# Altium schematic sheet BLDC_Motor_Reverser.SchDoc(BLDC_Motor_Reverser)
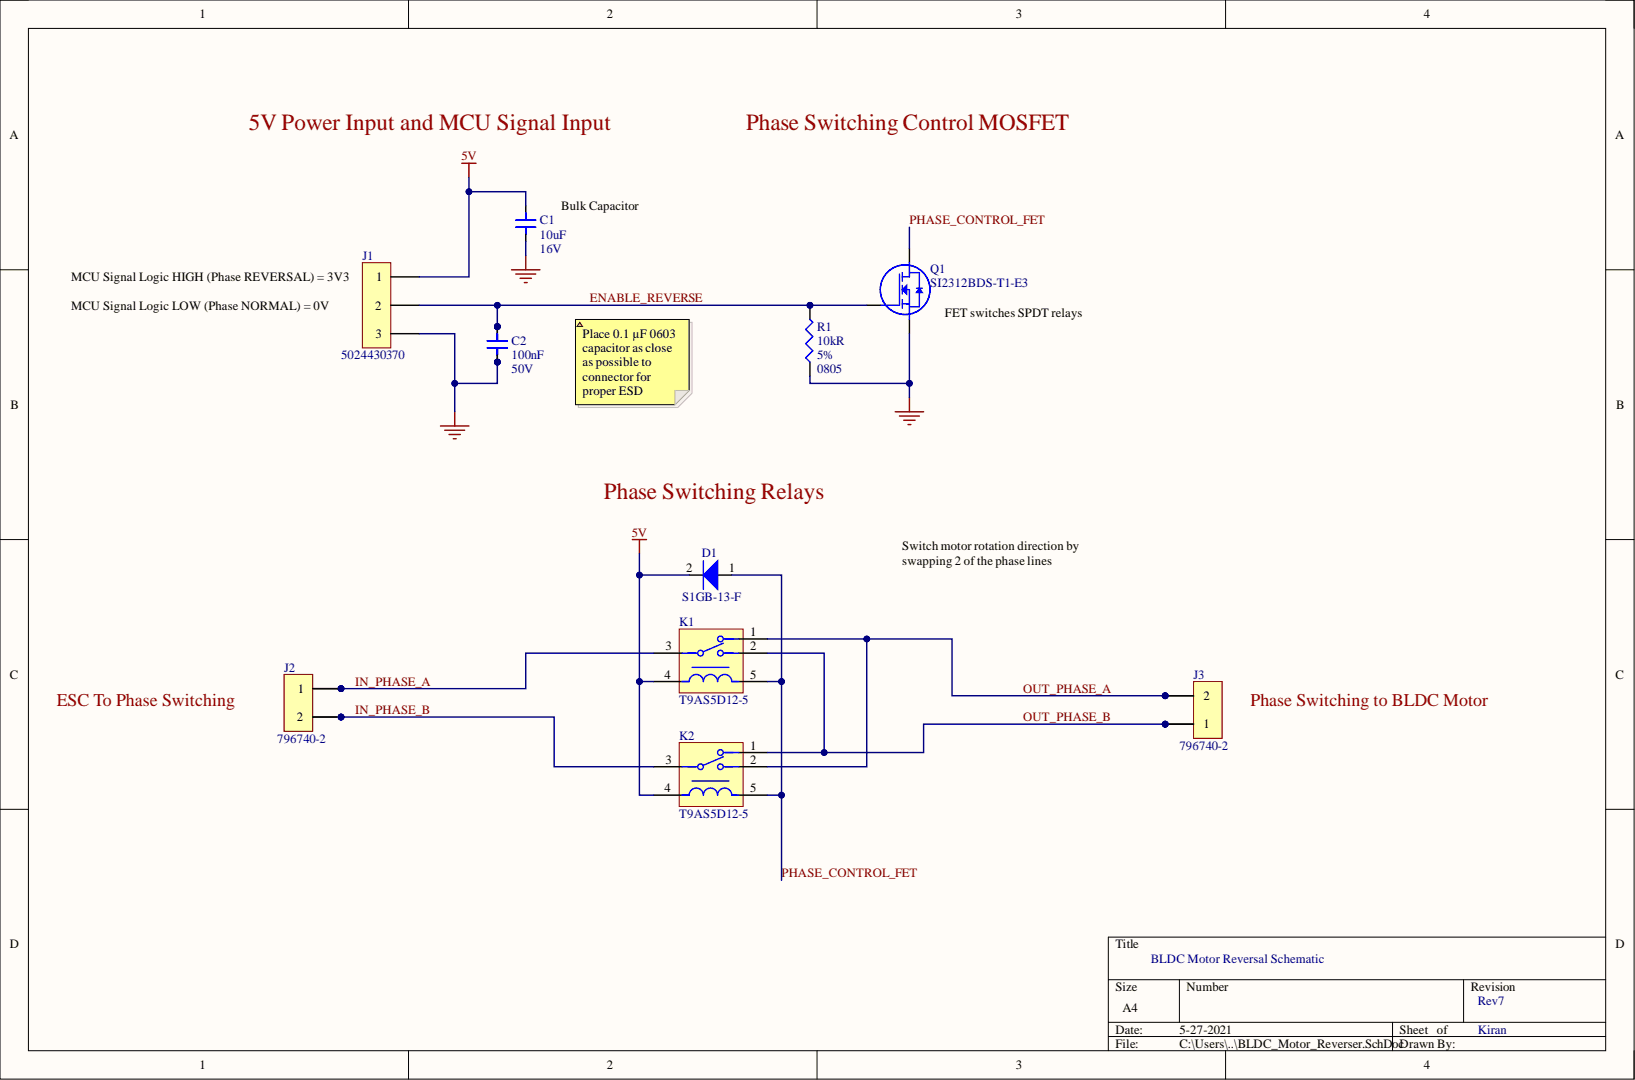
[diagram p0: BLDC_Motor_Reverser.SchDoc]

## Parts
C1  16V  Capacitance: 10uF  [CAP_0805_140mm]
C2  50V  Capacitance: 100nF  [CAP_0805_88mm]
D1  S1GB-13-F  [S1GB-13-F_DIODE]
J1  5024430370  [5024430370_MOLEX_CONN]
J2  796740-2  [CONN_796740-2]
J3  796740-2  [CONN_796740-2]
K1  T9AS5D12-5  [T9AS5D12-5_SPDT_RELAY]
K2  T9AS5D12-5  [T9AS5D12-5_SPDT_RELAY]
Q1  SI2312BDS-T1-E3  [SOT95P237X112-3N_NMOSFET]
R1  0805  Resistance: 10kR  Resistance Tolerance: 5%  [R0805_60mm]

## Nets
5V: C1.2 D1.2 J1.1 K1.4 K2.4
GND: C1.1 C2.1 J1.3 Q1.2 R1.1
ENABLE_REVERSE: C2.2 J1.2 Q1.1 R1.2
IN_PHASE_A: J2.1 K1.3
IN_PHASE_B: J2.2 K2.3
OUT_PHASE_A: J3.2 K1.1 K2.2
OUT_PHASE_B: J3.1 K1.2 K2.1
PHASE_CONTROL_FET: D1.1 K1.5 K2.5 Q1.3

## Library data of the parts
C1 = C0805C106K4PACTU: CERAMIC CAPACITOR, 10UF, 16V, X5R, 10%, 0805; Manufacturer: KEMET; Dissipation Factor: 5%; Case Code (Imperial): 0805; Case Code (Metric): 2012; Case/Package: 0805; Category: Ceramic Capacitors; Component Kind: Standard; ComponentLink1Description: https://datasheet.ciiva.com/7842/1732713-7842196.pdf?src-supplier=Element14; ComponentLink1URL: https://datasheet.ciiva.com/7842/1732713-7842196.pdf?src-supplier=Element14; ComponentLink2Description: https://datasheet.ciiva.com/11787/0900766b8116eb59-11787314.pdf?src-supplier=RSComponents; ComponentLink2URL: https://datasheet.ciiva.com/11787/0900766b8116eb59-11787314.pdf?src-supplier=RSComponents; ComponentLink3Description: https://datasheet.ciiva.com/28682/1831760-28682305.pdf?src-supplier=Element14; ComponentLink3URL: https://datasheet.ciiva.com/28682/1831760-28682305.pdf?src-supplier=Element14; ComponentLink4Description: https://datasheet.ciiva.com/26847/getdatasheetpartid-110990-26847815.pdf?src-supplier=Verical; ComponentLink4URL: https://datasheet.ciiva.com/26847/getdatasheetpartid-110990-26847815.pdf?src-supplier=Verical; ComponentLink5Description: https://datasheet.ciiva.com/7842/1732713-7842197.pdf?src-supplier=Element14; ComponentLink5URL: https://datasheet.ciiva.com/7842/1732713-7842197.pdf?src-supplier=Element14; Construction: Flat; Contact Plating: Tin,Matte; Depth: 1.25mm; Dielectric: X5R; Dielectric Material: Ceramic; Height: 1.25mm; Lead Free: Lead Free; Length: 2mm; Library Name: BLDC_Motor_Lib.SchLib; Manufacturer Part Number: C0805C106K4PACTU; Max Operating Temperature: 85°C; Min Operating Temperature: -55°C; Mount: Surface Mount; Number of Pins: 2; Package Quantity: 1; Packaging: Tape and Reel; Pricing 1: 1=0.21000, 10=0.14700, 50=0.08340, 100=0.06900, 500=0.04840, 1000=0.04007 (USD); Radiation Hardening: No; REACH SVHC: No SVHC; RoHS: Yes; Series: C; Stock 1: 386550; Supplier 1: Digi-Key; Supplier Part Number 1: 399-8012-1-ND; Termination: SMD/SMT; Thickness: 0.055inch; Tolerance: 10%; Voltage: 16V; Voltage Rating: 16V; Voltage Rating (DC): 16V; Width: 0.049inch
C2 = C0805C104K5RACTU: Cap Ceramic 0.1uF 50V X7R 10% SMD 2.0 x 1.2 mm 125 C Paper T/R; Manufacturer: KEMET; Voltage: 500V; Case Code (Imperial): 0805; Case Code (Metric): 2012; Case/Package: 0805; Category: Ceramic Capacitors; Component Kind: Standard; ComponentLink1Description: https://datasheet.ciiva.com/28682/1851736-28682232.pdf?src-supplier=Element14; ComponentLink1URL: https://datasheet.ciiva.com/28682/1851736-28682232.pdf?src-supplier=Element14; ComponentLink2Description: https://datasheet.ciiva.com/14589/70095383-14589048.pdf?src-supplier=Allied+Electronics; ComponentLink2URL: https://datasheet.ciiva.com/14589/70095383-14589048.pdf?src-supplier=Allied+Electronics; ComponentLink3Description: https://datasheet.ciiva.com/32431/slceramic-chip-condensers-e-32431755.pdf?src-supplier=Distrelec; ComponentLink3URL: https://datasheet.ciiva.com/32431/slceramic-chip-condensers-e-32431755.pdf?src-supplier=Distrelec; ComponentLink4Description: https://datasheet.ciiva.com/18410/70095383-18410815.pdf?src-supplier=Allied+Electronics; ComponentLink4URL: https://datasheet.ciiva.com/18410/70095383-18410815.pdf?src-supplier=Allied+Electronics; Construction: Flat; Contact Plating: Tin,Matte; Depth: 1.25mm; Dielectric: X7R; Dielectric Material: Ceramic; Height: 0.9mm; Lead Pitch: 0.75mm; Length: 2mm; Library Name: BLDC_Motor_Lib.SchLib; Manufacturer Part Number: C0805C104K5RACTU; Max Operating Temperature: 125°C; Min Operating Temperature: -55°C; Mount: Surface Mount,PCB; Number of Pins: 2; Package Quantity: 4000; Packaging: Tape and Reel; Pricing 1: 1=0.15000, 10=0.11000, 50=0.06220, 100=0.05150, 500=0.03612, 1000=0.02991 (USD); Radiation Hardening: No; REACH SVHC: No SVHC; RoHS: Yes; RoHS Compliant: Yes; Series: C0805C; Stock 1: 1084228; Supplier 1: Digi-Key; Supplier Part Number 1: 399-1170-1-ND; Termination: SMD/SMT; Thickness: 0.035inch; Tolerance: 10%; Voltage Rating: 50V; Voltage Rating (DC): 50V; Width: 1.2mm
D1 = S1GB-13-F: Flyback Diode for SPDT; IFSM: 8.0A; VRRM: 325V; Component Kind: Standard; Library Name: BLDC_Motor_Lib.SchLib
J1 = 5024430370: Molex Connectors for power and microcontroller signal; Component Kind: Standard; Library Name: BLDC_Motor_Lib.SchLib
J2 J3 = 796740-2: TERMINAL BLOCK, PLUG, 2POS, 24-10AWG; Connector Type:Eurostyle Terminal Block; Series:-; Connector Mounting:PCB; Pitch Spacing:6.35mm; No. of Contacts:2; Contact Plating:Tin; Contact Material:Phosphor Bronze; Manufacturer: TE Connectivity; Approvals: UL; Current: 30A; Interlock: Yes (With); Max Supply Voltage (AC): 300V; Number of Circuits: 2; Number of Levels: 1; Pitch: 6.35mm; Plating: Brass; Screw Flange: No (Without); Tail Length: 0.197inch; Thread Size: M3; Wire Gauge (Max): 24AWG; Category: Terminal Blocks; Color: Green; Component Kind: Standard; ComponentLink1Description: https://datasheet.ciiva.com/823/eng-cd-796740-c1-823477.pdf?src-supplier=Digi-Key; ComponentLink1URL: https://datasheet.ciiva.com/823/eng-cd-796740-c1-823477.pdf?src-supplier=Digi-Key; ComponentLink2Description: https://datasheet.ciiva.com/23766/eng-cd-796740-c1-23766211.pdf?src-supplier=Newark; ComponentLink2URL: https://datasheet.ciiva.com/23766/eng-cd-796740-c1-23766211.pdf?src-supplier=Newark; ComponentLink3Description: https://datasheet.ciiva.com/3141/eng-cs-1308389-eurostyle-terminal-blocks-0607-3141691.pdf?src-supplier=Avnet; ComponentLink3URL: https://datasheet.ciiva.com/3141/eng-cs-1308389-eurostyle-terminal-blocks-0607-3141691.pdf?src-supplier=Avnet; ComponentLink4Description: https://datasheet.ciiva.com/30130/eng-cs-1308389-eurostyle-terminal-blocks-0607-30130345.pdf?src-supplier=Onlinecomponents.com; ComponentLink4URL: https://datasheet.ciiva.com/30130/eng-cs-1308389-eurostyle-terminal-blocks-0607-30130345.pdf?src-supplier=Onlinecomponents.com; ComponentLink5Description: https://datasheet.ciiva.com/1416/1650926-1416332.pdf?src-supplier=Element14; ComponentLink5URL: https://datasheet.ciiva.com/1416/1650926-1416332.pdf?src-supplier=Element14; Connector Type: Plug; Contact Material: Brass; Contact Plating: Tin; Current Rating: 30A; Depth: 12.5mm; ELV: Compliant; Flammability Rating: UL94 V-0; Housing Color: Green; Housing Material: Polyamide; Lead Free: Lead Free; Length: 13.3mm; Library Name: BLDC_Motor_Lib.SchLib; Manufacturer Part Number: 796740-2; Max Current Rating: 30A; Max Voltage Rating (AC): 300V; Mount: Through Hole; Number of Contacts: 2; Number of Poles: 2; Number of Positions: 2; Number of Positions Per Level: 2; Number of Rows: 1; Orientation: Right Angle; Package Quantity: 200; Packaging: Carton; Pricing 1: 1=2.05000, 10=1.55100, 25=1.43760, 50=1.29260, 100=1.26100, 250=1.10336, 500=1.00878, 1000=0.91421, 5000=0.80388 (USD); Radiation Hardening: No; RoHS: Yes; RoHS Compliant: Yes; Screwless Terminal Block: No (Without); Series: Buchanan; Shrouded: No (Without); Side Stackable: No (Without); Stock 1: 2698; Supplier 1: Digi-Key; Supplier Part Number 1: A98275-ND; Termination: Screw; Voltage: 300V; Voltage Rating: 300V; Wire Gauge (Min): 24AWG
K1 K2 = T9AS5D12-5: RELAY GEN PURPOSE SPDT 20A 5V; Coil Current: 200mA; Coil Power: 1W; Coil Resistance: 25R; Coil Type: Non-Latching; Contact Voltage Rating (AC): 277V; Current Rating: 30A; Max Voltage Rating (DC): 28V; Operate Time: 15ms; Relay Type: General Purpose; Coil Voltage (DC): 5V; Component Kind: Standard; Contact Current Rating: 20A; Contact Material: Silver; Height: 20.4mm; Length: 32.5mm; Library Name: BLDC_Motor_Lib.SchLib; Max Operating Temperature: 85°C; Max Voltage Rating (AC): 277V; Min Operating Temperature: -55°C; Mount: Through Hole; Number of Pins: 5; Package Quantity: 50; Packaging: Bulk; Release Time: 15ms; RoHS Compliant: Yes; Series: T9A; Termination: PCB; Throw Configuration: SPDT; Voltage Rating (DC): 5V; Weight: 0.918oz; Width: 27.4mm
R1 = RC0805JR-0710KL: Res Thick Film 0805 10K Ohm 5% 1/8W ±100ppm/°C Molded SMD Paper T/R; Manufacturer: Yageo; Max Power Dissipation: 125mW; Voltage Rating: 150V; Case Code (Imperial): 0805; Case Code (Metric): 2012; Case/Package: 0805; Category: Chip SMD Resistors; Component Kind: Standard; ComponentLink1Description: https://datasheet.ciiva.com/7941/444164-7941870.pdf?src-supplier=Element14; ComponentLink1URL: https://datasheet.ciiva.com/7941/444164-7941870.pdf?src-supplier=Element14; ComponentLink2Description: https://datasheet.ciiva.com/7941/444164-7941871.pdf?src-supplier=Element14; ComponentLink2URL: https://datasheet.ciiva.com/7941/444164-7941871.pdf?src-supplier=Element14; ComponentLink3Description: https://datasheet.ciiva.com/7983/444164-7983765.pdf?src-supplier=Element14; ComponentLink3URL: https://datasheet.ciiva.com/7983/444164-7983765.pdf?src-supplier=Element14; Construction: Rectangular; Contact Plating: Tin,Matte; Depth: 1.25mm; Features: Moisture Resistant; Height: 0.5mm; Lead Free: Lead Free; Length: 2mm; Library Name: BLDC_Motor_Lib.SchLib; Manufacturer Part Number: RC0805JR-0710KL; Max Operating Temperature: 155°C; Military Standard: Not; Min Operating Temperature: -55°C; Number of Terminations: 2; Packaging: Tape and Reel; Power Rating: 125mW; Pricing 1: 1=0.10000, 10=0.03600, 100=0.01450, 1000=0.00652, 2500=0.00566 (USD); Radiation Hardening: No; REACH SVHC: Unknown; Resistor Type: Thick Film; RoHS: Yes; RoHS Compliant: Yes; Series: RC; Size Code: 0805; Stock 1: 4966716; Supplier 1: Digi-Key; Supplier Part Number 1: 311-10KARCT-ND; Temperature Coefficient: 100ppm/°C; Termination: SMD/SMT; Tolerance: 5%; Width: 1.25mm
Q1 = SI2312BDS-T1-E3: Component Kind: Standard; Library Name: BLDC_Motor_Lib.SchLib
